# Altium schematic sheet TGCC-HC-1 RF Module 3-2-2020.SchDoc
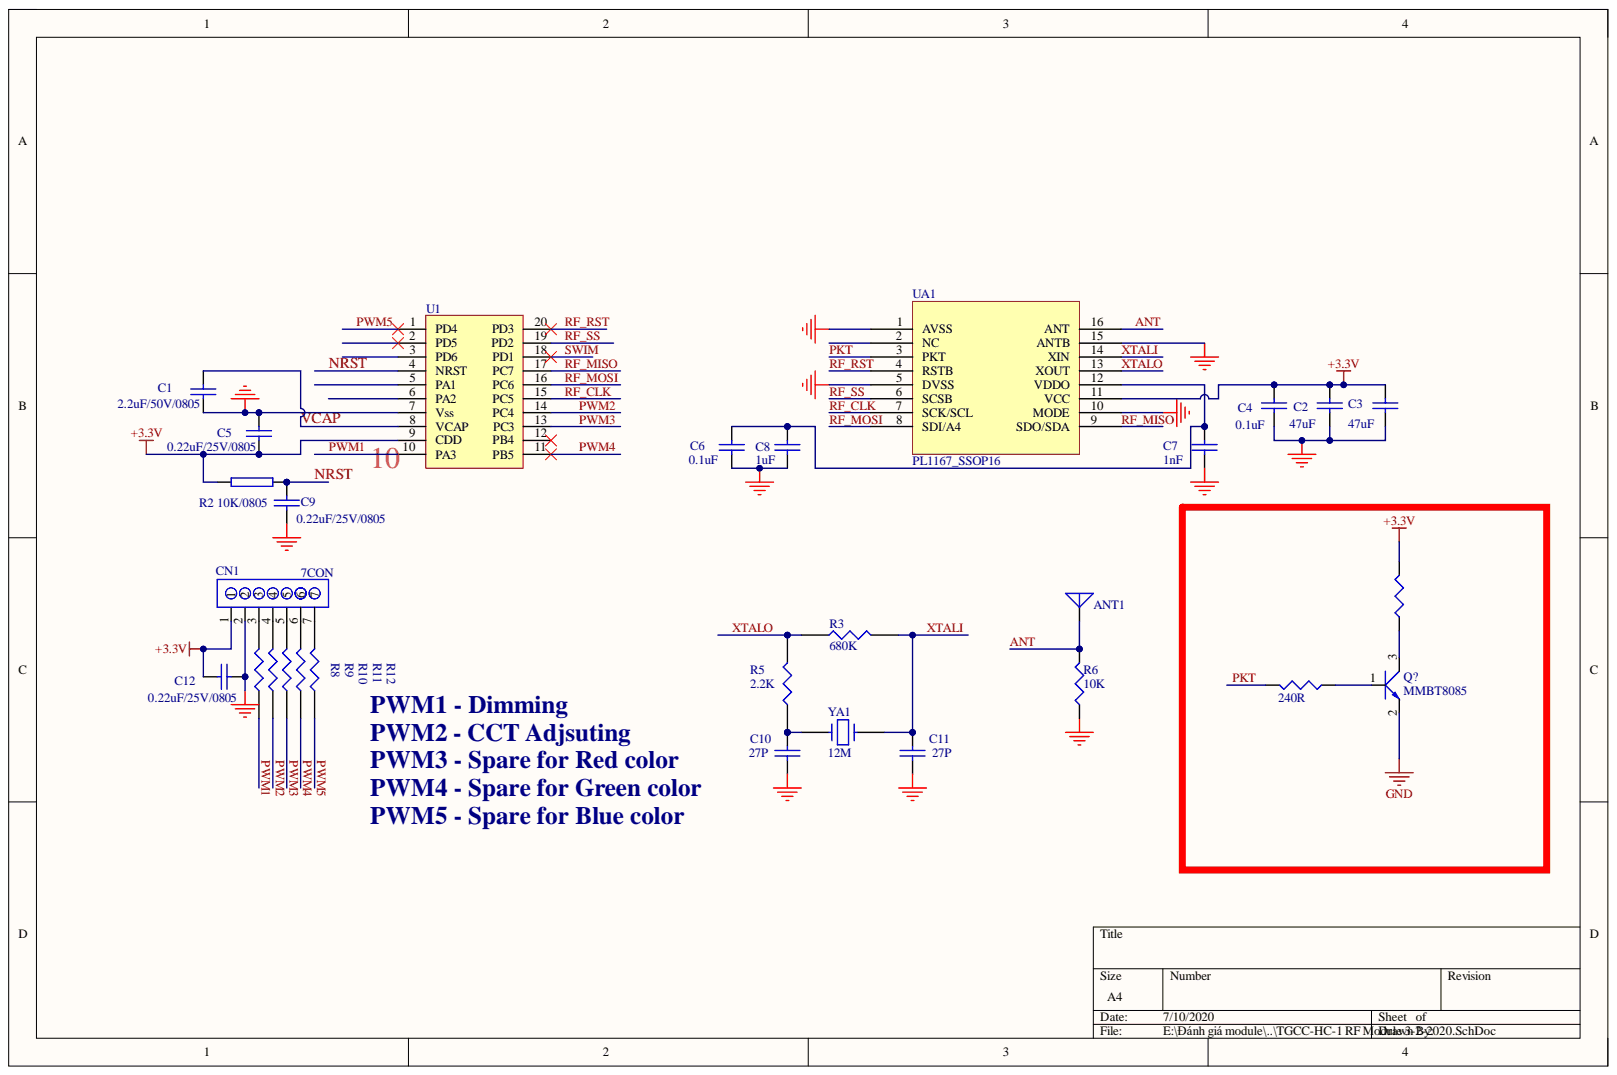
[diagram p0: TGCC-HC-1 RF Module 3-2-2020.SchDoc]

## Parts
ANT1  (no value)
C1  2.2uF/50V/0805  [0402]
C2  47uF  [0402]
C3  47uF  [0402]
C4  0.1uF  [0402]
C5  0.22uF/25V/0805  [0402]
C6  0.1uF  [0402]
C7  1nF  [0402]
C8  1uF  [0402]
C9  0.22uF/25V/0805  [0402]
C10  27P  [0402]
C11  27P  [0402]
C12  0.22uF/25V/0805  [0402]
CN1  7CON  [HDR1X7]
Q?  MMBT8085  [TO92-123]
R2  10K/0805  [0402]
R3  680K  [0402]
R5  2.2K  [0402]
R6  10K  [0402]
R8  (no value)  [0402]
R9  (no value)  [0402]
R10  (no value)  [0402]
R11  (no value)  [0402]
R12  (no value)  [0402]
R?  240R  [0402]
R?  (no value)  [0402]
U1  (no value)  [MCU]
UA1  PL1167_SSOP16  [SSOP-16]
YA1  12M  [XTAL-SMD]

## Nets
+3.3V: C2.1 C3.1 C4.1 C5.2 C12.1 CN1.1 R2.2 R?.2 U1.9(CDD) UA1.11(VCC)
GND: C1.2 C2.2 C3.2 C4.2 C5.1 C6.2 C7.2 C8.2 C9.2 C10.2 C11.2 C12.2 CN1.2 Q?.2 R6.1 U1.7(Vss) UA1.1(AVSS) UA1.5(DVSS) UA1.10(MODE) UA1.15(ANTB)
ANT: ANT1.1 R6.2 UA1.16(ANT)
NRST: C9.1 R2.1 U1.4(NRST)
PKT: R?.2 UA1.3(PKT)
PWM1: R8.1 U1.10(PA3)
PWM2: R9.1 U1.14(PC4)
PWM3: R10.1 U1.13(PC3)
PWM4: R11.1 U1.11(PB5)
PWM5: R12.1 U1.1(PD4)
RF_CLK: U1.15(PC5) UA1.7(SCK/SCL)
RF_MISO: U1.17(PC7) UA1.9(SDO/SDA)
RF_MOSI: U1.16(PC6) UA1.8(SDI/A4)
RF_RST: U1.20(PD3) UA1.4(RSTB)
RF_SS: U1.19(PD2) UA1.6(SCSB)
SWIM: U1.18(PD1)
VCAP: C1.1 U1.8(VCAP)
XTALI: C11.1 R3.2 UA1.14(XIN) YA1.2
XTALO: R3.1 R5.2 UA1.13(XOUT)
NetC6_1: C6.1 C7.1 C8.1 UA1.12(VDDO)
NetC10_1: C10.1 R5.1 YA1.1
NetCN1_3: CN1.3 R8.2
NetCN1_4: CN1.4 R9.2
NetCN1_5: CN1.5 R10.2
NetCN1_6: CN1.6 R11.2
NetCN1_7: CN1.7 R12.2
NetQ?_1: Q?.1 R?.1
NetQ?_3: Q?.3 R?.1
N00000:

Not connected: U1 2(PD5) 3(PD6) 5(PA1) 6(PA2) 12(PB4); UA1 2(NC)

## Library data of the parts
ANT1 = ANTENNA: Generic Antenna; Component Kind: Standard
C1 C5 C9 C12 = CAP: Component Kind: Standard
C2-C4 C6-C8 C10 C11 = CAP: Capacitor; Component Kind: Standard
Q? = 2SC1815: NPN 600mW, ECB; Value: C1815; : C828; : C2383; Component Kind: Standard; Library Name: MD.IntLib
R2 = RES2: Component Kind: Standard; Library Name: Backup of anthonysch.lib
R3 R5 R6 R8-R12 R? R? = RES1: Component Kind: Standard
U1 = STM8S103: Component Kind: Standard; Library Name: 钜景电子SCH.lib
UA1 = PL1167: Component Kind: Standard; Library Name: MD.IntLib
YA1 = CRYSTAL: Component Kind: Standard; Description: Crystal
CN1 = 7CON: Component Kind: Standard; Library Name: 钜景电子SCH.lib
